# Altium schematic sheet AIRZAI_BASE_BD_REVC Sheet2.SchDoc
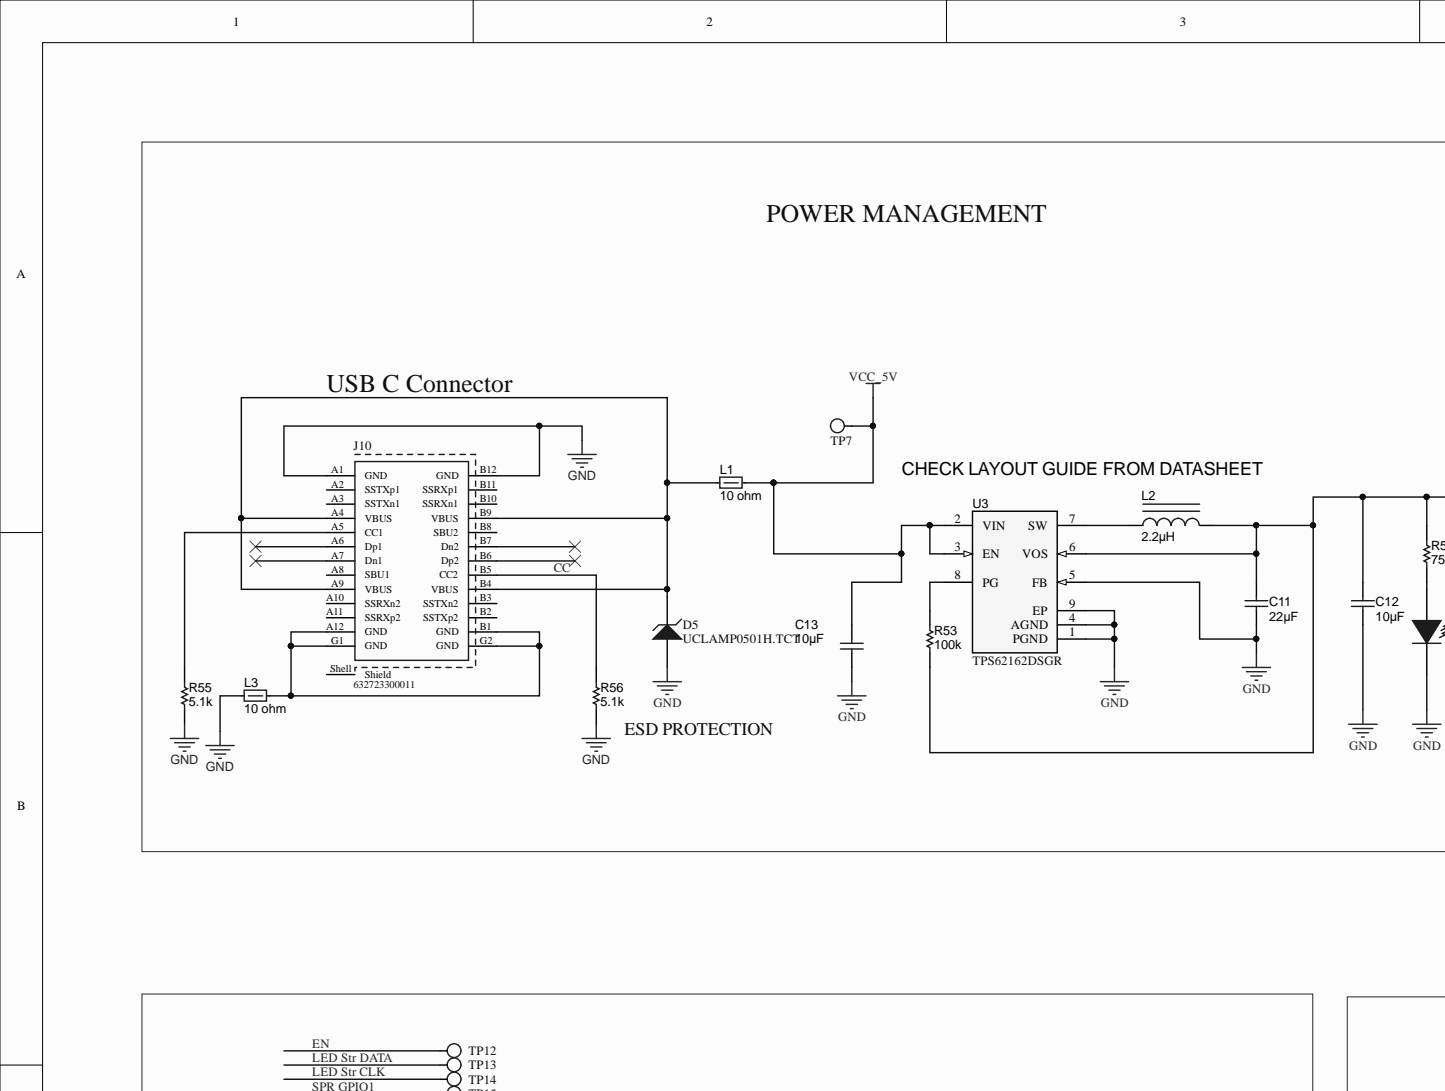
[diagram p0: AIRZAI_BASE_BD_REVC Sheet2.SchDoc, top left]
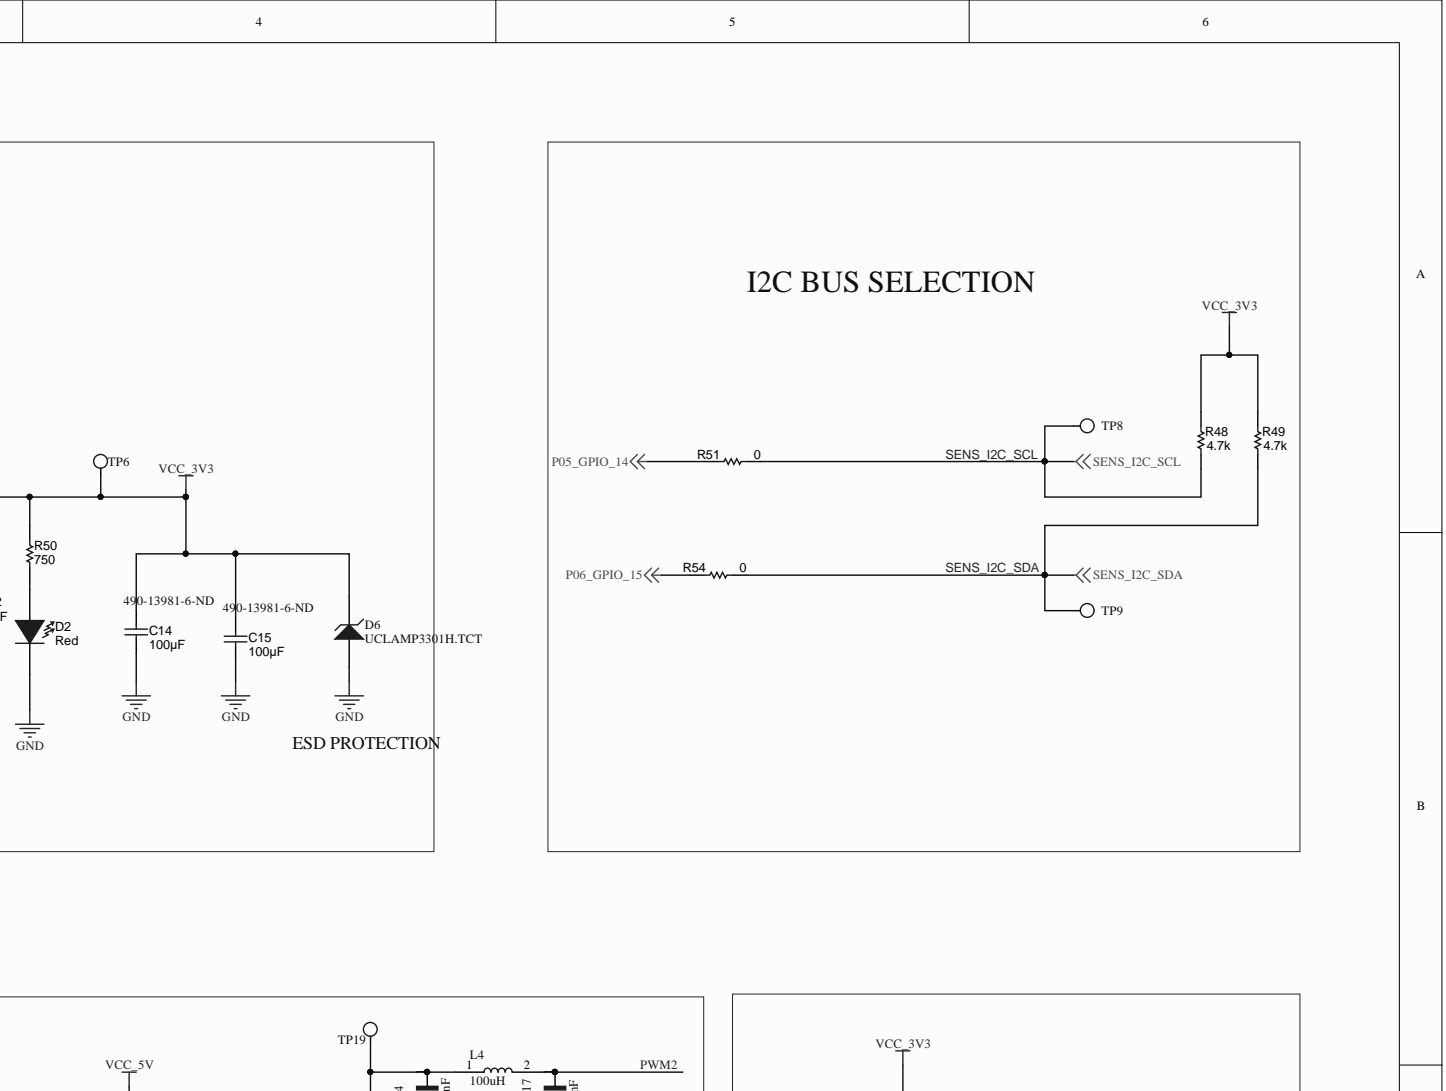
[diagram p1: AIRZAI_BASE_BD_REVC Sheet2.SchDoc, top right]
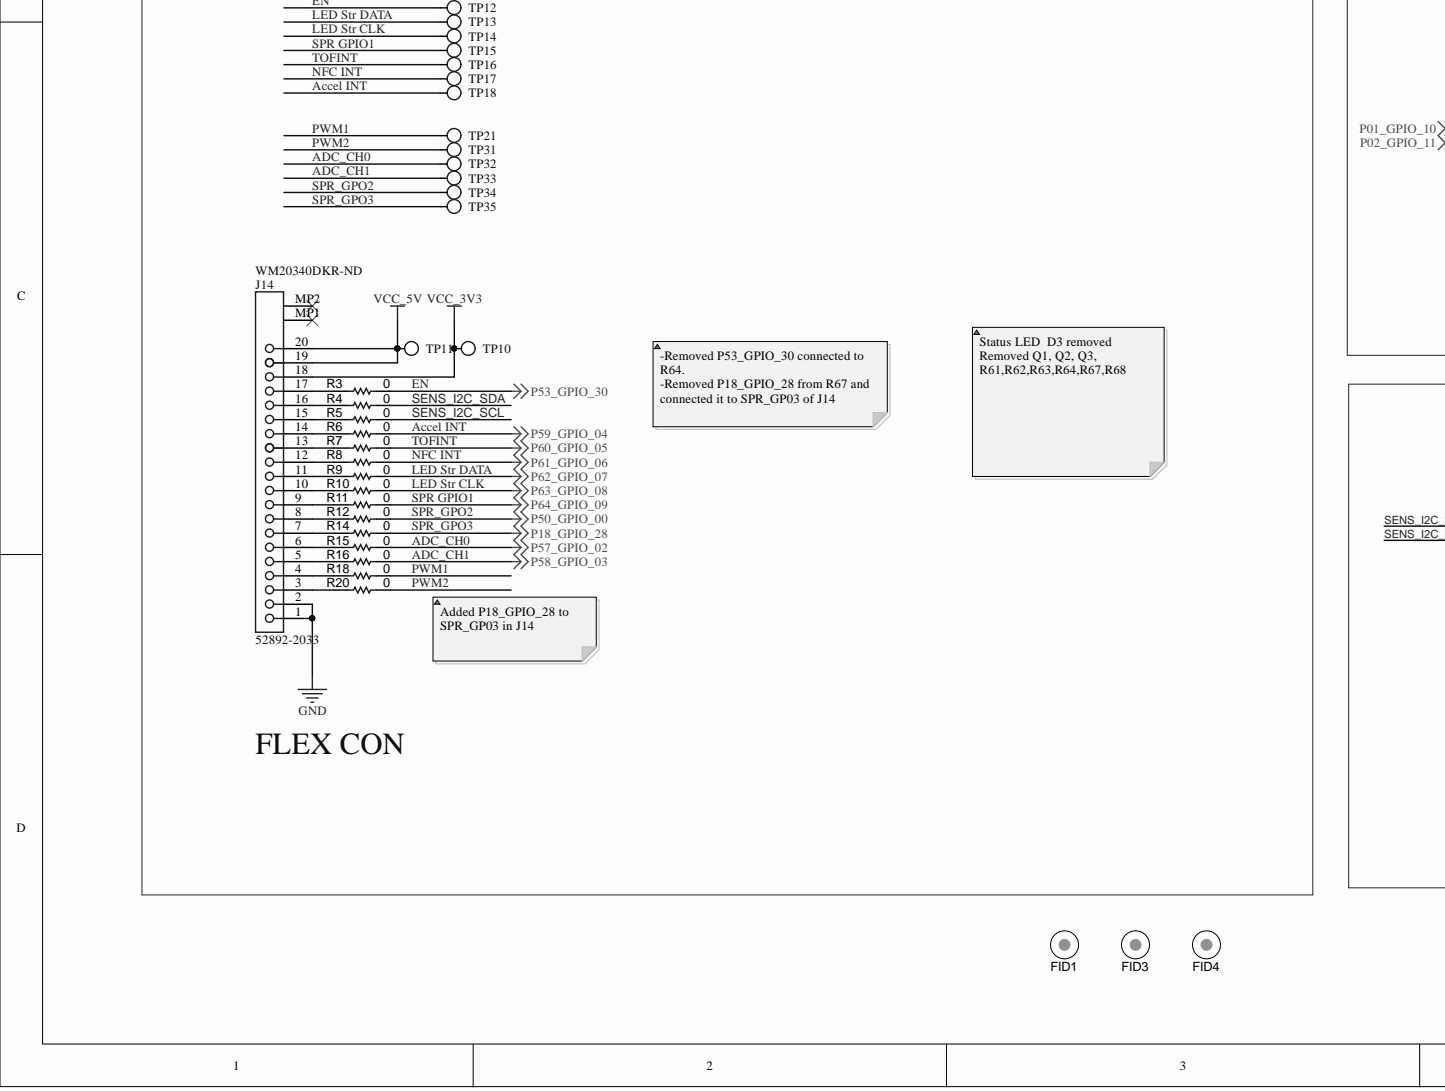
[diagram p2: AIRZAI_BASE_BD_REVC Sheet2.SchDoc, bottom left]
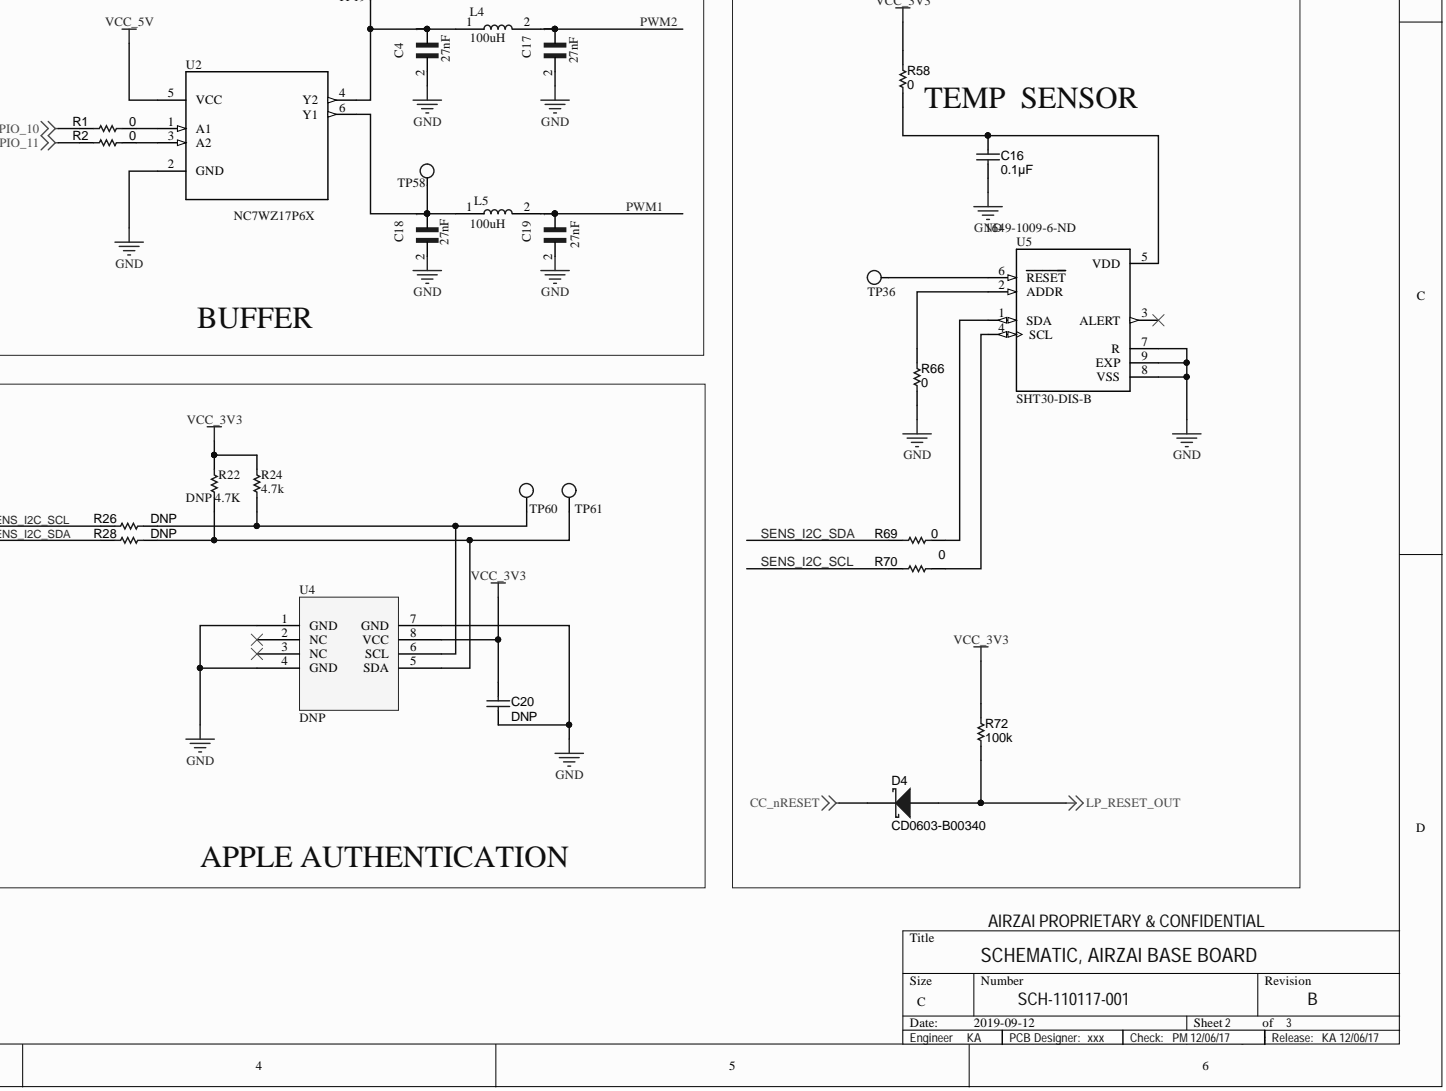
[diagram p3: AIRZAI_BASE_BD_REVC Sheet2.SchDoc, bottom right]

## Parts
C4  value 27nF  [1206CAP]  (hidden comment: GRM3195C1H273JA01D)
C11  ValueDisplayed: 22µF  [0805_HV]  (hidden comment: C2012X5R1C226K125AC)
C12  ValueDisplayed: 10µF  [0805_HV]  (hidden comment: GRM21BR61A106KE19L)
C13  ValueDisplayed: 10µF  [0805_HV]  (hidden comment: GRM21BR61A106KE19L)
C14  Supplier Part Number 3: 490-13981-6-ND  ValueDisplayed: 100µF  [1206_180]  (hidden comment: GRM31CR60J107ME39L)
C15  Supplier Part Number 3: 490-13981-6-ND  ValueDisplayed: 100µF  [1206_180]  (hidden comment: GRM31CR60J107ME39L)
C16  ValueDisplayed: 0.1µF  [0402]  (hidden comment: GRM155R61A104KA01D)
C17  value 27nF  [1206CAP]  (hidden comment: GRM3195C1H273JA01D)
C18  value 27nF  [1206CAP]  (hidden comment: GRM3195C1H273JA01D)
C19  value 27nF  [1206CAP]  (hidden comment: GRM3195C1H273JA01D)
C20  value DNP  [SM0402_BTD.1SM_ACT_H55_CAP_WSS]  (hidden comment: C1005X5R1A104K050BA)
D2  value Red  [SML-31_Red]  (hidden comment: SML-311UTT86)
D4  CD0603-B00340  [Diode_0603]
D5  UCLAMP0501H.TCT  [SOD-523]
D6  UCLAMP3301H.TCT  [SOD-523]
FID1  Fiducial  [Fiducial10-20]  (value not drawn)
FID3  Fiducial  [Fiducial10-20]  (value not drawn)
FID4  Fiducial  [Fiducial10-20]  (value not drawn)
J10  632723300011  [632723300011]
J14  52892-2033  Supplier Part Number 1: WM20340DKR-ND  [MOLX-52892-2033]
L1  value 10 ohm  [0402]  (hidden comment: BLM15AG100SN1D)
L2  ValueDisplayed: 2.2µH  [0805_HV]  (hidden comment: BRC2012T2R2MD)
L3  value 10 ohm  [0402]  (hidden comment: BLM15AG100SN1D)
L4  value 100uH  [0805_Inductor]  (hidden comment: MLF2012C101KTD25)
L5  value 100uH  [0805_Inductor]  (hidden comment: MLF2012C101KTD25)
R1  ERJ-2GE0R00X  [0402]  (value not drawn)
R2  ERJ-2GE0R00X  [0402]  (value not drawn)
R3  value 0  [0402]  (hidden comment: ERJ-2GE0R00X)
R4  value 0  [0402]  (hidden comment: ERJ-2GE0R00X)
R5  value 0  [0402]  (hidden comment: ERJ-2GE0R00X)
R6  value 0  [0402]  (hidden comment: ERJ-2GE0R00X)
R7  value 0  [0402]  (hidden comment: ERJ-2GE0R00X)
R8  value 0  [0402]  (hidden comment: ERJ-2GE0R00X)
R9  value 0  [0402]  (hidden comment: ERJ-2GE0R00X)
R10  value 0  [0402]  (hidden comment: ERJ-2GE0R00X)
R11  value 0  [0402]  (hidden comment: ERJ-2GE0R00X)
R12  value 0  [0402]  (hidden comment: ERJ-2GE0R00X)
R14  value 0  [0402]  (hidden comment: ERJ-2GE0R00X)
R15  value 0  [0402]  (hidden comment: ERJ-2GE0R00X)
R16  value 0  [0402]  (hidden comment: ERJ-2GE0R00X)
R18  value 0  [0402]  (hidden comment: ERJ-2GE0R00X)
R20  value 0  [0402]  (hidden comment: ERJ-2GE0R00X)
R22  Resistance (Ohms): DNP 4.7K  [0402]  (hidden comment: RMCF0402JT4K70)
R24  Resistance (Ohms): 4.7k  [0402]  (hidden comment: RMCF0402JT4K70)
R26  ValueDisplayed: DNP  [0402]  (hidden comment: ERJ-2GE0R00X)
R28  ValueDisplayed: DNP  [0402]  (hidden comment: ERJ-2GE0R00X)
R48  value 4.7k  [0402]
R49  value 4.7k  [0402]
R50  value 750  [0603]  (hidden comment: RC0603JR-07750RL)
R51  value 0  [0402]  (hidden comment: ERJ-2GE0R00X)
R53  value 100k  [0402]  (hidden comment: CRCW0402100KJNED)
R54  value 0  [0402]  (hidden comment: ERJ-2GE0R00X)
R55  ValueDisplayed: 5.1k  [0402]  (hidden comment: CRCW040210K0JNED)
R56  ValueDisplayed: 5.1k  [0402]  (hidden comment: CRCW040210K0JNED)
R58  value 0  [0402]  (hidden comment: ERJ-2GE0R00X)
R66  value 0  [0402]  (hidden comment: ERJ-2GE0R00X)
R69  value 0  [0402]  (hidden comment: ERJ-2GE0R00X)
R70  value 0  [0402]  (hidden comment: ERJ-2GE0R00X)
R72  value 100k  [0402]  (hidden comment: CRCW0402100KJNED)
TP6  WIREPT  [TP_0.9MM]  (value not drawn)
TP7  WIREPT  [TP_0.9MM]  (value not drawn)
TP8  WIREPT  [TP_0.9MM]  (value not drawn)
TP9  WIREPT  [TP_0.9MM]  (value not drawn)
TP10  WIREPT  [TP_0.9MM]  (value not drawn)
TP11  WIREPT  [TP_0.9MM]  (value not drawn)
TP12  WIREPT  [TP_0.9MM]  (value not drawn)
TP13  WIREPT  [TP_0.9MM]  (value not drawn)
TP14  WIREPT  [TP_0.9MM]  (value not drawn)
TP15  WIREPT  [TP_0.9MM]  (value not drawn)
TP16  WIREPT  [TP_0.9MM]  (value not drawn)
TP17  WIREPT  [TP_0.9MM]  (value not drawn)
TP18  WIREPT  [TP_0.9MM]  (value not drawn)
TP19  WIREPT  [TP_0.9MM]  (value not drawn)
TP21  WIREPT  [TP_0.9MM]  (value not drawn)
TP31  WIREPT  [TP_0.9MM]  (value not drawn)
TP32  WIREPT  [TP_0.9MM]  (value not drawn)
TP33  WIREPT  [TP_0.9MM]  (value not drawn)
TP34  WIREPT  [TP_0.9MM]  (value not drawn)
TP35  WIREPT  [TP_0.9MM]  (value not drawn)
TP36  WIREPT  [TP_0.9MM]  (value not drawn)
TP58  WIREPT  [TP_0.9MM]  (value not drawn)
TP60  TESTPOINT  [TP_0.9MM]  (value not drawn)
TP61  TESTPOINT  [TP_0.9MM]  (value not drawn)
U2  NC7WZ17P6X  [SC-70-NC7WZ17P6X]
U3  TPS62162DSGR  [DSG0008A]
U4  DNP  [XDFN-8P-Apple]
U5  SHT30-DIS-B  Supplier Part Number 1: 1649-1009-6-ND  [DFN250X250X100-9N]

## Nets
CC_nRESET: D4.1
GND: C4.2 C11.2 C12.2 C13.2 C14.2 C15.2 C16.2 C17.2 C18.2 C19.2 C20.2 D2.1 D5.A D6.A J10.A1(GND) J10.B12(GND) J14.1 J14.2 L3.2 R55.2 R56.2 R66.1 U2.2(GND) U3.1(PGND) U3.4(AGND) U3.5(FB) U3.9(EP) U4.1(GND) U4.4(GND) U4.7(GND) U5.7(R) U5.8(VSS) U5.9(EXP)
LP_RESET_OUT: D4.2 R72.2
P01_GPIO_10: R1.1
P02_GPIO_11: R2.1
P05_GPIO_14: R51.1
P06_GPIO_15: R54.1
VCC_3V3: C11.1 C12.1 C14.1 C15.1 C20.1 D6.K J14.18 L2.2 R22.2 R24.2 R48.1 R49.1 R50.2 R53.2 R58.2 R72.1 TP6.1 TP10.1 U3.6(VOS) U4.8(VCC)
VCC_5V: C13.1 J14.19 J14.20 L1.2 TP7.1 TP11.1 U2.5(VCC) U3.2(VIN) U3.3(EN)
Accel INT: R6.2 TP18.1
ADC_CH0: R15.2 TP32.1
ADC_CH1: R16.2 TP33.1
CC: J10.B5(CC2) R56.1
EN: R3.2 TP12.1
LED Str CLK: R10.2 TP14.1
LED Str DATA: R9.2 TP13.1
NFC INT: R8.2 TP17.1
PWM1: C19.1 L5.2 R18.2 TP21.1
PWM2: C17.1 L4.2 R20.2 TP31.1
SENS_I2C_SCL: R5.2 R26.1 R48.2 R51.2 R70.1 TP8.1
SENS_I2C_SDA: R4.2 R28.1 R49.2 R54.2 R69.1 TP9.1
SPR GPIO1: R11.2 TP15.1
SPR_GPO2: R12.2 TP34.1
SPR_GPO3: R14.2 TP35.1
TOFINT: R7.2 TP16.1
NetC4_1: C4.1 L4.1 TP19.1 U2.4(Y2)
NetC16_1: C16.1 R58.1 U5.5(VDD)
NetC18_1: C18.1 L5.1 TP58.1 U2.6(Y1)
NetD2_2: D2.2 R50.1
NetD5_K: D5.K J10.A4(VBUS) J10.A9(VBUS) J10.B4(VBUS) J10.B9(VBUS) L1.1
NetJ10_A2: J10.A2(SSTXp1)
NetJ10_A3: J10.A3(SSTXn1)
NetJ10_A5: J10.A5(CC1) R55.1
NetJ10_A6: J10.A6(Dp1)
NetJ10_A7: J10.A7(Dn1)
NetJ10_A8: J10.A8(SBU1)
NetJ10_A10: J10.A10(SSRXn2)
NetJ10_A11: J10.A11(SSRXp2)
NetJ10_A12: J10.A12(GND) J10.B1(GND) J10.G1(GND) J10.G2(GND) L3.1
NetJ10_B2: J10.B2(SSTXp2)
NetJ10_B3: J10.B3(SSTXn2)
NetJ10_B6: J10.B6(Dp2)
NetJ10_B7: J10.B7(Dn2)
NetJ10_B8: J10.B8(SBU2)
NetJ10_B10: J10.B10(SSRXn1)
NetJ10_B11: J10.B11(SSRXp1)
NetJ10_Shell: J10.Shell(Shield)
NetJ14_3: J14.3 R20.1
NetJ14_4: J14.4 R18.1
NetJ14_5: J14.5 R16.1
NetJ14_6: J14.6 R15.1
NetJ14_7: J14.7 R14.1
NetJ14_8: J14.8 R12.1
NetJ14_9: J14.9 R11.1
NetJ14_10: J14.10 R10.1
NetJ14_11: J14.11 R9.1
NetJ14_12: J14.12 R8.1
NetJ14_13: J14.13 R7.1
NetJ14_14: J14.14 R6.1
NetJ14_15: J14.15 R5.1
NetJ14_16: J14.16 R4.1
NetJ14_17: J14.17 R3.1
NetJ14_MP1: J14.MP1
NetJ14_MP2: J14.MP2
NetL2_1: L2.1 U3.7(SW)
NetR1_2: R1.2 U2.1(A1)
NetR2_2: R2.2 U2.3(A2)
NetR22_1: R22.1 R28.2 TP61.1 U4.5(SDA)
NetR24_1: R24.1 R26.2 TP60.1 U4.6(SCL)
NetR53_1: R53.1 U3.8(PG)
NetR66_2: R66.2 U5.2(ADDR)
NetR69_2: R69.2 U5.1(SDA)
NetR70_2: R70.2 U5.4(SCL)
NetTP36_1: TP36.1 U5.6(RESET)
NetU4_2: U4.2(NC)
NetU4_3: U4.3(NC)
NetU5_3: U5.3(ALERT)

## Library data of the parts
C4 C17-C19 = GRM3195C1H273JA01D: 1206 27 nF 50 V Â±5% Tolerance C0G SMT Multilayer Ceramic Capacitor; MF: Murata Electronics; Availability: Unavailable; Component Kind: Standard; Library Name: GRM3195C1H273JA01D.IntLib; MP: GRM3195C1H273JA01D; Package: 1206 Murata; Price: None; SnapEDA_Link: https://www.snapeda.com/parts/GRM3195C1H273JA01D/Murata%20Electronics%20North%20America/view-part/?ref=snap
C11 = C2012X5R1C226K125AC: CAP, CERM, 22 µF, 16 V, +/- 10%, X5R, 0805; Manufacturer: TDK; AEC Category: Q200; Cap_Case: 0805; Cap_F: 0.000022; CompType: Capacitor; Polarized: N; SizeArea_mm2: 2.5; SizeAreaWB_mm2: 6.75; SizeX_mm: 2; SizeY_mm: 1.25; SizeZ_mm: 1.45; TaMax_C: 85; TaMin_C: -55; Tolerance_%: 10; U/M: EA; Value: 22uF; Value1: Unknown; Value2: 16V; VDC_V: 16; AEC Qualified: ?; Component Kind: Standard; ComponentLink1Description: Datasheet link; ComponentLink1URL: http://product.tdk.com/capacitor/mlcc/en/documents/C2012X5R1C226K125AC.pdf; LatestRevisionDate: 2016-07-02; Library Name: Capacitor_Ceramic.IntLib; MountType: SMT; PackageReference: 0805; PartNumber: C2012X5R1C226K125AC; Published: 2008-06-19; RoHS: Y; Signal Integrity: Cap; Simulation: Cap; Supplier 1: Digi-Key; Supplier 2: Mouser; Supplier 3: Farnell; Supplier Part Number 1: 445-6797-1-ND; Supplier Part Number 2: 810-C2012X5R1C226K; Supplier Part Number 3: 2346925; SymbolVersion: 2008-07-09; Technology: Ceramic; TempCo: X5R; Publisher: Texas Instruments
C12 C13 = GRM21BR61A106KE19L: CAP, CERM, 10 µF, 10 V, +/- 10%, X5R, 0805; Manufacturer: MuRata; AEC Category: Q200; Cap_Case: 0805; Cap_F: 0.00001; CompType: Capacitor; ESR_ohm: 0.002; Polarized: N; SizeArea_mm2: 2.5; SizeAreaWB_mm2: 6.75; SizeX_mm: 2; SizeY_mm: 1.25; SizeZ_mm: 1.45; TaMax_C: 85; TaMin_C: -55; Tolerance_%: 10; U/M: EA; Value: 10uF; Value1: 0.002 ohm; Value2: 10V; AEC Qualified: ?; Component Kind: Standard; ComponentLink1Description: Datasheet link; ComponentLink1URL: http://search.murata.co.jp/Ceramy/PnsearchViewAction.do?HnOFG=ON&HnNFG=ON&SEL_CNT=50&sLang=en&sKey=GRM21BR61A106KE19L&x=9&y=9&search=startwith; LatestRevisionDate: 2016-07-02; Library Name: Capacitor_Ceramic.IntLib; MountType: SMT; PackageReference: 0805; PartNumber: GRM21BR61A106KE19L; Published: 2008-06-19; RoHS: Y; Signal Integrity: Cap; Simulation: Cap; Supplier 1: Digi-Key; Supplier 2: Mouser; Supplier 3: Farnell; Supplier Part Number 1: 490-1709-1-ND; Supplier Part Number 2: 81-GRM21BR61A106KE19; Supplier Part Number 3: 1828805; SymbolVersion: 2008-07-09; Technology: Ceramic; TempCo: X5R; VDC_V: 10; Publisher: Texas Instruments
C14 C15 = GRM31CR60J107ME39L: CAP, CERM, 100 µF, 6.3 V, +/- 20%, X5R, 1206; Manufacturer: MuRata; AEC Category: Q200; Cap_Case: 1206; Cap_F: 0.0001; CompType: Capacitor; ESR_ohm: 0.003; Polarized: N; SizeArea_mm2: 5.12; SizeAreaWB_mm2: 10.92; SizeX_mm: 3.2; SizeY_mm: 1.6; SizeZ_mm: 1.8; TaMax_C: 85; TaMin_C: -55; Tolerance_%: 20; U/M: EA; Value: 100uF; Value1: 0.003 ohm; Value2: 6.3V; VDC_V: 6.3; AEC Qualified: ?; Component Kind: Standard; ComponentLink1Description: Datasheet link; ComponentLink1URL: http://search.murata.co.jp/Ceramy/PnsearchViewAction.do?HnOFG=ON&HnNFG=ON&SEL_CNT=50&sLang=en&sKey=GRM31CR60J107ME39L&x=9&y=9&search=startwith; LatestRevisionDate: 2016-07-02; Library Name: Capacitor_Ceramic.IntLib; MountType: SMT; PackageReference: 1206; PartNumber: GRM31CR60J107ME39L; Published: 2008-06-19; RoHS: Y; Signal Integrity: Cap; Simulation: Cap; Supplier 1: Mouser; Supplier 2: Farnell; Supplier 3: Digi-Key; Supplier Part Number 1: 81-GRM31CR60J107ME9L; Supplier Part Number 2: 1735535; SymbolVersion: 2008-07-09; Technology: Ceramic; TempCo: X5R; Publisher: Texas Instruments
C16 = GRM155R61A104KA01D: CAP, CERM, 0.1 µF, 10 V, +/- 10%, X5R, 0402; Manufacturer: MuRata; AEC Category: Q200; Cap_F: 0.0000001; CompType: Capacitor; Polarized: N; SizeArea_mm2: 0.5; SizeAreaWB_mm2: 3; SizeX_mm: 1; SizeZ_mm: 0.6; TaMax_C: 85; TaMin_C: -55; Tolerance_%: 10; U/M: EA; Value: 0.1uF; Value1: Unknown; Value2: 10V; AEC Qualified: ?; Cap_Case: 0402; Component Kind: Standard; ComponentLink1Description: Datasheet link; ComponentLink1URL: http://search.murata.co.jp/Ceramy/PnsearchViewAction.do?HnOFG=ON&HnNFG=ON&SEL_CNT=50&sLang=en&sKey=GRM155R61A104KA01D&x=9&y=9&search=startwith; LatestRevisionDate: 2016-08-14; Library Name: Capacitor_Ceramic.IntLib; MountType: SMT; PackageReference: 0402; PartNumber: GRM155R61A104KA01D; Published: 2008-06-19; RoHS: Y; Signal Integrity: Cap; Simulation: Cap; SizeY_mm: 0.5; Supplier 1: Digi-Key; Supplier 2: Mouser; Supplier 3: Farnell; Supplier Part Number 1: 490-1318-1-ND; Supplier Part Number 2: 81-GRM155R61A104KA01; Supplier Part Number 3: 1828862; SymbolVersion: 2008-07-09; Technology: Ceramic; TempCo: X5R; VDC_V: 10; Publisher: Texas Instruments
C20 = C1005X5R1A104K050BA: CAP, CERM, 0.1 µF, 10 V, +/- 10%, X5R, 0402; Manufacturer: TDK; Cap_Case: SM0402_BTD.1SM_ACT; Cap_F: 0.0000001; CompType: Capacitor; Polarized: N; TaMax_C: 85; TaMin_C: -55; Tolerance_%: 10; U/M: EA; Value1: Unknown; Value2: 10V; Component Kind: Standard; ComponentLink1Description: Datasheet link; ComponentLink1URL: http://product.tdk.com/en/catalog/datasheets/mlcc_commercial_general_en.pdf; LatestRevisionDate: 2015-02-23; MountType: SMT; PackageReference: 0402; PartNumber: C1005X5R1A104K050BA; Published: 2008-06-19; RoHS: Y; Supplier 1: Digi-Key; Supplier 2: Mouser; Supplier 3: Farnell; Supplier Part Number 1: 445-1265-1-ND; Supplier Part Number 2: 810-C1005X5R1A104K; Supplier Part Number 3: 2368215; SymbolVersion: 2008-07-09; Technology: Ceramic; TempCo: X5R; ValueDisplayed: DNP; VDC_V: 10; Library Name: AIRZAI_MBD_REVB2.IntLib; Publisher: Texas Instruments
D2 = SML-311UTT86: LED, Red, SMD; Manufacturer: Rohm; Io_A: 0.002; Type: AlInGaP; U/M: EA; Value1: W; Value2: 1.8V; Vf_V: 1.8; Color: red; Component Kind: Standard; ComponentLink1Description: Datasheet link; ComponentLink1URL: http://rohmfs.rohm.com/en/products/databook/datasheet/opto/led/chip_mono/sml-31.pdf; CompType: LED; LatestRevisionDate: 2016-06-29; Library Name: LED.IntLib; MountType: SMT; PackageReference: LED, 1.6x.8x.8mm; PartNumber: SML-311UTT86; Published: 2008-07-11; RoHS: Y; Signal Integrity: Diode; Supplier 1: Digi-Key; Supplier 2: Mouser; Supplier 3: Farnell; Supplier Part Number 1: 511-1304-1-ND; Supplier Part Number 2: 755-SML-311UTT86; Supplier Part Number 3: 1685068; SymbolVersion: 2008-11-06; Publisher: Texas Instruments
D4 = CD0603-B00340: Diode, Schottky, 45 V, 0.03 A, 0603 Diode; Manufacturer: Bourns; AEC Category: Q101; Io_A: 0.03; SizeArea_mm2: 1.53; SizeAreaWB_mm2: 5.13; SizeX_mm: 1.7; SizeY_mm: 0.9; SizeZ_mm: 0.75; TJmax_C: 125; U/M: EA; Value: 45V; Value1: 0.03A; Value2: 0.37V; Vf_V: 0.37; Vr_V: 45; AEC Qualified: ?; Component Kind: Standard; ComponentLink1Description: Datasheet link; ComponentLink1URL: http://www.bourns.com/pdfs/SBCD0603_1005.pdf; CompType: Diode; LatestRevisionDate: 2016-07-03; Library Name: Diode.IntLib; MountType: SMT; PackageReference: 0603 Diode; PartNumber: CD0603-B00340; Published: 2008-06-26; RoHS: Y; Signal Integrity: Diode; Supplier 1: Mouser; Supplier 2: Farnell; Supplier Part Number 1: 652-CD0603-B00340; Supplier Part Number 2: 1456534; SymbolVersion: 2008-12-08; Type: Schottky; Publisher: Texas Instruments
D5 = UCLAMP0501H.TCT: TVS DIODE 5V 12.5V SOD523; Manufacturer: Semtech; Breakdown Voltage: 6V; Capacitance: 160pF; Direction: Unidirectional; Element Configuration: Single; Leakage Current: 5uA; Max Power Dissipation: 240W; Number of Channels: 1; Peak Pulse Current: 16A; Power Line Protection: No; Test Current: 1mA; Clamping Voltage: 12.5V; Component Kind: Standard; ComponentLink1Description: https://www.semtech.com/uploads/documents/uclamp3301h.pdf?src-supplier=Digi-Key; ComponentLink1URL: https://www.semtech.com/uploads/documents/uclamp3301h.pdf?src-supplier=Digi-Key; ComponentLink2Description: https://www.verical.com/server-webapp/html/getDatasheet?partID=141337&src-supplier=Verical; ComponentLink2URL: https://www.verical.com/server-webapp/html/getDatasheet?partID=141337&src-supplier=Verical; ComponentLink3Description: http://www.semtech.com/images/datasheet/uclamp3301h.pdf?src-supplier=Avnet; ComponentLink3URL: http://www.semtech.com/images/datasheet/uclamp3301h.pdf?src-supplier=Avnet; ComponentLink4Description: http://www.semtech.com/images/datasheet/uclamp0501h.pdf?src-supplier=Future+Electronics; ComponentLink4URL: http://www.semtech.com/images/datasheet/uclamp0501h.pdf?src-supplier=Future+Electronics; ComponentLink5Description: https://www.verical.com/server-webapp/html/getDatasheet?partID=51047&src-supplier=Verical; ComponentLink5URL: https://www.verical.com/server-webapp/html/getDatasheet?partID=51047&src-supplier=Verical; ComponentLink6Description: http://www.semtech.com/images/datasheet/uclamp0501h.pdf?src-supplier=Digi-Key; ComponentLink6URL: http://www.semtech.com/images/datasheet/uclamp0501h.pdf?src-supplier=Digi-Key; ComponentLink7Description: https://www.semtech.com/uploads/documents/uclamp0501h.pdf?src-supplier=Digi-Key; ComponentLink7URL: https://www.semtech.com/uploads/documents/uclamp0501h.pdf?src-supplier=Digi-Key; ComponentLink8Description: http://www.semtech.com/images/datasheet/uclamp0501h.pdf?src-supplier=Element14; ComponentLink8URL: http://www.semtech.com/images/datasheet/uclamp0501h.pdf?src-supplier=Element14; ComponentLink9Description: http://www.farnell.com/datasheets/1796175.pdf?src-supplier=Element14; ComponentLink9URL: http://www.farnell.com/datasheets/1796175.pdf?src-supplier=Element14; ComponentLink10Description: http://www.semtech.com/images/datasheet/uclamp0501h.pdf?src-supplier=Avnet; ComponentLink10URL: http://www.semtech.com/images/datasheet/uclamp0501h.pdf?src-supplier=Avnet; Composition: Zener; Depth: 0.9mm; Lead Free: Lead Free; Length: 1.3mm; Manufacturer Part Number: UCLAMP0501H.TCT; Max Breakdown Voltage: 6V; Max Operating Temperature: 125°C; Min Breakdown Voltage: 6V; Min Operating Temperature: -55°C; Mount: Surface Mount; Number of Elements: 1; Number of Pins: 2; Number of Unidirectional Channels: 1; Packaging: Tape and Reel; PartNumber: UCLAMP0501H.TCT; Peak Pulse Power: 240W; Polarity: Unidirectional; Pricing 1: 1=0.65, 10=0.568, 25=0.5028, 100=0.438, 250=0.38124, 500=0.32446, 1000=0.25956 (USD); Radiation Hardening: No; REACH SVHC: No SVHC; Reverse Breakdown Voltage: 6V; Reverse Standoff Voltage: 5V; Signal Integrity: D Zener; Simulation: ZENER; Stock 1: 63859; Supplier 1: Digi-Key; Supplier Part Number 1: UCLAMP0501HDKR-ND; Voltage Rating (DC): 5V; Width: 900um; Working Voltage: 5V; Library Name: AIRZAI_BASE_BD_REVA.SCHLIB
D6 = UCLAMP3301H.TCT: TVS DIODE 3.3V 8V SOD523; Manufacturer: Semtech; Capacitance: 30pF; Direction: Unidirectional; Element Configuration: Single; Leakage Current: 500nA; Max Power Dissipation: 40W; Min Breakdown Voltage: 3.5V; Number of Channels: 1; Peak Pulse Current: 5A; Power Line Protection: No; Clamping Voltage: 8V; Component Kind: Standard; ComponentLink1Description: https://www.semtech.com/uploads/documents/uclamp3301h.pdf?src-supplier=Digi-Key; ComponentLink1URL: https://www.semtech.com/uploads/documents/uclamp3301h.pdf?src-supplier=Digi-Key; ComponentLink2Description: https://www.verical.com/server-webapp/html/getDatasheet?partID=141337&src-supplier=Verical; ComponentLink2URL: https://www.verical.com/server-webapp/html/getDatasheet?partID=141337&src-supplier=Verical; ComponentLink3Description: http://www.semtech.com/images/datasheet/uclamp3301h.pdf?src-supplier=Avnet; ComponentLink3URL: http://www.semtech.com/images/datasheet/uclamp3301h.pdf?src-supplier=Avnet; Composition: Zener; Depth: 0.9mm; Lead Free: Lead Free; Length: 1.3mm; Manufacturer Part Number: UCLAMP3301H.TCT; Max Operating Temperature: 125°C; Min Operating Temperature: -55°C; Mount: Surface Mount; Number of Elements: 1; Number of Pins: 2; Number of Unidirectional Channels: 1; Operating Supply Voltage: 3.3V; Packaging: Tape and Reel; PartNumber: UCLAMP3301H.TCT; Peak Pulse Power: 40W; Polarity: Unidirectional; Pricing 1: 1=0.71, 10=0.554, 50=0.554, 100=0.357, 1000=0.286, 10000=0.225 (USD); Radiation Hardening: No; REACH SVHC: No SVHC; Reverse Standoff Voltage: 3.3V; Signal Integrity: D Zener; Simulation: ZENER; Stock 1: 89004; Supplier 1: Mouser; Supplier Part Number 1: 947-UCLAMP3301H.TCT; Thickness: 700um; Voltage Rating (DC): 3.3V; Width: 900um; Working Voltage: 3.3V; Library Name: AIRZAI_BASE_BD_REVA.SCHLIB
FID1 FID3 FID4 = Fiducial: Fiducial mark.  There is nothing to buy or mount.; SymbolCreator: codlsc; ClassName: FiducialMark; Component Kind: Standard; CompType: Fiducial; LatestRevisionDate: 2016-05-09; LatestRevisionNote: Added Alternate PartNumber and Alternate Manufacturer parameters to appropriate components.; Library Name: MiscParts.IntLib; Manufacturer: N/A; MountType: N/A; PackageReference: N/A; PartNumber: N/A; SymbolVersion: 2009-11-4; Publisher: Texas Instruments
J10 = 632723300011: USB 3.1 Type C Receptacle WR-COM, Horizontal, SMT / THT; Contact Resistance: 30 mOhm; Rated Current: 5 A; Working Voltage: 20 VDC; Component Kind: Standard; ComponentLink1Description: Manufacturer; ComponentLink1URL: http://www.we-online.de; ComponentLink2Description: Datasheet; ComponentLink2URL: https://katalog.we-online.de/em/datasheet/632723x00011%282%29.pdf; Connector Type: USB 3.1 Type C; Gender: Receptacle; Library Name: Altium_WR-COM_USB-Connectors (rev18b).IntLib; Mounting Technology: SMT / THT; Operating Temperature: -40 to 105 degC; Orientation: Horizontal; Packaging: Tape and Reel; Part Number: 632723300011; Published Date: September 2018; Publisher: Wurth Elektronik
J14 = CMP-1241-00473-1: 0.5 mm Pitch Easy-On(TM) Type FPC Connector, 2.0 mm Mated Height, Right Angle, ZIF, SMT, Bottom Contact, 20 Circuits, -40 to 85 degC, ELV and RoHS Compliant, Tape and Reel; Manufacturer: Molex; Circuits (Loaded): 20; Current (Max per Contact): 0.5A; Entry Angle: 90degrees Angle; Mated Height: 2.00mm; Material - Metal: Phosphor Bronze; Material - Plating Mating: Silver, Tin-Bismuth; PCB Locator: No; PCB Retention: Yes; Pitch - Mating Interface: 0.50mm; Voltage (Max): 50V; Component Kind: Standard; ComponentLink1Description: Manufacturer URL; ComponentLink1URL: http://www.molex.com/molex/index.jsp; ComponentLink2Description: Datasheet; ComponentLink2URL: http://www.molex.com/webdocs/datasheets/pdf/en-us/0528922033_FFC_FPC_CONNECTORS.pdf; ComponentLink3Description: Package Specification; ComponentLink3URL: http://www.molex.com/pdm_docs/sd/528922033_sd.pdf; Contact Position: Bottom; Durability (mating cycles max): 20; Library Name: Altium Content Vault; Material - Plating Termination: Silver, Tin-Bismuth; Mounting Technology: Surface Mount; Number of Rows: 1; Orientation: Right Angle; PackageDescription: 20-Lead FPC Connector, Pitch 0.5 mm; PackageReference: 52892-2033; PackageVersion: Rev. A, 05/2013; Packing: Tape and Reel; PartNumber: 52892-2033; Pitch - Termination Interface: 0.50mm; Polarized to PCB: No; RoHS: TRUE; Stackable: No; Supplier 1: Digi-Key
L1 L3 = BLM15AG100SN1D: Ferrite Bead, 10 ohm @ 100 MHz, 1 A, 0402; Manufacturer: MuRata; DCR_ohm: 0.025; Imax_A: 1; Value1: 0.025 ohm; Value2: 1A; ZTyp@100MHz_Ohm: 10; Component Kind: Standard; ComponentLink1Description: Datasheet link; ComponentLink1URL: http://search.murata.co.jp/Ceramy/image/img/PDF/ENG/L0110S0100BLM15AG_SN.pdf; CompType: Ferrite Bead; LatestRevisionDate: 2016-06-23; Library Name: FerriteBead.IntLib; MountType: SMT; PackageReference: 0402; PartNumber: BLM15AG100SN1D; Published: 2014-04-30; RoHS: Y; Supplier 1: Digi-Key; Supplier 2: Mouser; Supplier 3: Farnell; Supplier Part Number 1: 490-5176-1-ND; Supplier Part Number 2: 81-BLM15AG100SN1D; Supplier Part Number 3: 1515758; SymbolVersion: 2009-06-02; Publisher: Texas Instruments
L2 = BRC2012T2R2MD: Inductor, Wirewound, 2.2 µH, 1 A, 0.143 ohm, SMD; Manufacturer: Taiyo Yuden; AEC Category: Q200; DCR_ohm: 0.143; Imax_A: 1; Ind_H: 2.2E-6; Irms: 1A @ 40C rise; Isat: 1.1A @ 30% inductance drop; Value: 2.2uH; Value2: 1A; AEC Qualified: ?; Component Kind: Standard; ComponentLink1Description: Datasheet link; ComponentLink1URL: http://www.yuden.co.jp/ut/product/category/inductor/BRC2012T2R2MD.pdf; CompType: Inductor; LatestRevisionDate: 2016-06-28; Library Name: Inductor_Other.IntLib; Material: ?; MountType: SMT; PackageReference: 0805; PartNumber: BRC2012T2R2MD; Published: 2008-06-26; RoHS: Y; Signal Integrity: Inductor; Simulation: Inductor; Supplier 1: Digi-Key; Supplier 2: Mouser; Supplier 3: Farnell; Supplier Part Number 1: 587-2905-1-ND; Supplier Part Number 2: 963-BRC2012T2R2MD; Supplier Part Number 3: 2369453; SymbolVersion: 2008-07-23; Technology: Wirewound; Value1: 0.143 ohm; Publisher: Texas Instruments
L4 L5 = MLF2012C101KTD25: Fixed Ind 100uh 2ma 3.1 Ohm Smd; MF: TDK; Availability: Unavailable; Component Kind: Standard; Library Name: MLF2012C101KTD25.IntLib; MP: MLF2012C101KTD25; Package: 0805 TDK; Price: None; SnapEDA_Link: https://www.snapeda.com/parts/MLF2012C101KTD25/TDK-Lambda%20Americas%20Inc/view-part/?ref=snap
R1 R2 R26 R28 = ERJ-2GE0R00X: RES, 0, 5%, 0.063 W, 0402; Manufacturer: Panasonic; AEC Category: Q200; CompType: Resistor; EIA_Code: E24; MF: Panasonic Electronic Components; Power_W: 0.063; Res_ohm: 0; SizeArea_mm2: 0.5; SizeX_mm: 1; SizeZ_mm: 0.6; TempCo: 600 ppm/C; Tolerance_%: 5; U/M: EA; Value2: 0.063W; Value_Code: 0000; VDC_V: 50; AEC Qualified: ?; Component Kind: Standard; ComponentLink1Description: Datasheet link; ComponentLink1URL: http://www.panasonic.com/industrial/components/pdf/AOA0000CE1.pdf; Digi-Key_Part_Number: P0.0JCT-ND; Digi-Key_Purchase_URL: https://www.digikey.ca/products/en?keywords=P0.0JCT-ND; LatestRevisionDate: 2016-07-01; Library Name: Resistor_0402.IntLib; MountType: SMT; MP: ERJ-2GE0R00X; Package: 0402 (1005 Metric); PackageReference: 0402; PartNumber: ERJ-2GE0R00X; Published: 2008-06-26; RoHS: Y; Signal Integrity: Resistor; Simulation: Resistor; SizeY_mm: 0.5; Supplier 1: Digi-Key; Supplier 2: Mouser; Supplier 3: Farnell; Supplier Part Number 1: P0.0JCT-ND; Supplier Part Number 2: 667-ERJ-2GE0R00X; Supplier Part Number 3: 2059190; SymbolVersion: 2009-05-29; Technology: Thick Film; Value1: 5%; Publisher: Texas Instruments
  R1 R2: ValueDisplayed: 0
R3-R12 R14-R16 R18 R20 R51 R54 R58 R66 R69 R70 = ERJ-2GE0R00X: RES, 0, 5%, 0.063 W, 0402; Manufacturer: Panasonic; AEC Category: Q200; CompType: Resistor; EIA_Code: E24; Power_W: 0.063; SizeArea_mm2: 0.5; SizeX_mm: 1; SizeZ_mm: 0.6; TempCo: 600 ppm/C; Tolerance_%: 5; U/M: EA; Value2: 0.063W; Value_Code: 0000; VDC_V: 50; AEC Qualified: ?; Component Kind: Standard; ComponentLink1Description: Datasheet link; ComponentLink1URL: http://www.panasonic.com/industrial/components/pdf/AOA0000CE1.pdf; LatestRevisionDate: 2016-07-01; Library Name: Resistor_0402.IntLib; MountType: SMT; PackageReference: 0402; PartNumber: ERJ-2GE0R00X; Published: 2008-06-26; Res_ohm: 0; RoHS: Y; Signal Integrity: Resistor; Simulation: Resistor; SizeY_mm: 0.5; Supplier 1: Digi-Key; Supplier 2: Mouser; Supplier 3: Farnell; Supplier Part Number 1: P0.0JCT-ND; Supplier Part Number 2: 667-ERJ-2GE0R00X; Supplier Part Number 3: 2059190; SymbolVersion: 2009-05-29; Technology: Thick Film; Value1: 5%; ValueDisplayed: 0; Publisher: Texas Instruments
R22 R24 = RES-2: Chip Resistor, 4.7 KOhm, +/- 5%, 0.063 W, -55 to 155 degC, 0402 (1005 Metric), RoHS, Tape and Reel; Manufacturer: Stackpole-Electronics-Inc.; Power (Watts): 0.063W,1/16W; Temperature Coefficient: ±100ppm/°C; Category: Resistors; Component Kind: Standard; Composition: ThickFilm; Datasheet URL: https://www.seielect.com/Catalog/SEI-RMCF_RMCP.pdf; Datasheet Version: 01/2017; Family: ChipResistor-SurfaceMount; Features: AutomotiveAEC-Q200; Manufacturer Part.No: RMCF0402JT4K70; Manufacturer URL: https://www.seielect.com/; Mounting Technology: SurfaceMount; Number of Terminations: 2; Operating Temperature: -55°C~155°C; Package / Case: 0402(1005Metric); Package Description: 2-Pin Surface Mount Device, Body 1 x 0.5 mm; Package Reference: 0402; Packaging: Digi-Reel®; Series: RMCF; Supplier 1: Digi-Key; Supplier Device Package: 0402; Supplier Part Number 1: RMCF0402JT4K70-ND; Tolerance: ±5%; Library Name: AIRZAI_BASE_BD_REVA.SCHLIB
R48 R49 = CRCW04023K30JNED: RES SMD 4.7K OHM 1% 1/16W 0402; Manufacturer: Yageo; Manufacturer Part Number: RC0402FR-074K7L; Power Rating: 62.5mW; Resistance: 4.7kR; Temperature Coefficient: 100ppm/°C; Voltage Rating: 50V; Case/Package: 0402; Case Code (Imperial): 0402; Case Code (Metric): 1005; Component Kind: Standard; ComponentLink1Description: Datasheet link; ComponentLink1URL: http://www.vishay.com/docs/20035/dcrcwe3.pdf; ComponentLink2Description: http://documents.rs-components.com/techlib://itc_doclist_GB.htm?stockno=6184558&src-supplier=RSComponents; ComponentLink2URL: http://documents.rs-components.com/techlib://itc_doclist_GB.htm?stockno=6184558&src-supplier=RSComponents; ComponentLink3Description: http://www.farnell.com/datasheets/1018594.pdf?src-supplier=Element14; ComponentLink3URL: http://www.farnell.com/datasheets/1018594.pdf?src-supplier=Element14; ComponentLink4Description: https://www.verical.com/server-webapp/html/getDatasheet?partID=328119&src-supplier=Verical; ComponentLink4URL: https://www.verical.com/server-webapp/html/getDatasheet?partID=328119&src-supplier=Verical; ComponentLink5Description: http://www.farnell.com/datasheets/1686128.pdf?src-supplier=Element14; ComponentLink5URL: http://www.farnell.com/datasheets/1686128.pdf?src-supplier=Element14; Composition: Thick Film; Depth: 0.5mm; Height: 0.32mm; Lead Free: Lead Free; Length: 1mm; Library Name: Resistor_0402.IntLib; Max Operating Temperature: 155°C; Military Standard: Not; Min Operating Temperature: -55°C; Number of Pins: 2; Packaging: Tape and Reel; Pricing 1: 1=0.1, 10=0.016, 100=0.0067, 1000=0.00301, 2500=0.00261, 5000=0.00215 (USD); REACH SVHC: No SVHC; Signal Integrity: Resistor; Simulation: Resistor; Stock 1: 27955304; Supplier 1: Digi-Key; Supplier Part Number 1: 311-4.7KLRDKR-ND; Termination: SMD/SMT; Tolerance: 1%; Voltage Rating (DC): 50V; Width: 0.5mm
R50 = RC0603JR-07750RL: RES, 750, 5%, 0.1 W, 0603; Manufacturer: Yageo America; AEC Category: Q200; CompType: Resistor; EIA_Code: E24; Power_W: 0.1; SizeArea_mm2: 1.28; SizeX_mm: 1.6; SizeY_mm: 0.8; SizeZ_mm: 1; TempCo: 100 ppm/C; Tolerance_%: 5; U/M: EA; Value2: 0.1W; Value_Code: 750R; VDC_V: 50; AEC Qualified: ?; Component Kind: Standard; ComponentLink1Description: Datasheet link; ComponentLink1URL: http://www.yageo.com/pdf/Pu-RC0603_51_PbFree_L_2.pdf; LatestRevisionDate: 2016-11-02; Library Name: Resistor_0603.IntLib; MountType: SMT; PackageReference: 0603; PartNumber: RC0603JR-07750RL; Published: 2008-06-26; Res_ohm: 750; RoHS: Y; Signal Integrity: Resistor; Simulation: Resistor; Supplier 1: Digi-Key; Supplier 2: Mouser; Supplier 3: Farnell; Supplier Part Number 1: 311-750GRCT-ND; Supplier Part Number 2: 603-RC0603JR-07750RL; Supplier Part Number 3: 1799450; SymbolVersion: 2009-05-29; Technology: Thick Film; Value1: 5%; ValueDisplayed: 750; Publisher: Texas Instruments
R53 R72 = CRCW0402100KJNED: RES, 100 k, 5%, 0.063 W, 0402; Manufacturer: Vishay-Dale; AEC Category: Q200; CompType: Resistor; EIA_Code: E24; Power_W: 0.063; Res_ohm: 100000; SizeArea_mm2: 0.5; SizeX_mm: 1; SizeZ_mm: 0.6; TempCo: 200 ppm/C; Tolerance_%: 5; U/M: EA; Value2: 0.063W; VDC_V: 50; AEC Qualified: ?; Component Kind: Standard; ComponentLink1Description: Datasheet link; ComponentLink1URL: http://www.vishay.com/docs/20035/dcrcwe3.pdf; LatestRevisionDate: 2016-07-01; Library Name: Resistor_0402.IntLib; MountType: SMT; PackageReference: 0402; PartNumber: CRCW0402100KJNED; Published: 2008-06-26; RoHS: Y; Signal Integrity: Resistor; Simulation: Resistor; SizeY_mm: 0.5; Supplier 1: Digi-Key; Supplier 2: Mouser; Supplier 3: Farnell; Supplier Part Number 1: 541-100KJCT-ND; Supplier Part Number 2: 71-CRCW0402J-100K-E3; Supplier Part Number 3: 1652738; SymbolVersion: 2009-05-29; Technology: Thick Film; Value1: 5%; Value_Code: 100k; ValueDisplayed: 100k; Publisher: Texas Instruments
R55 R56 = CRCW040210K0JNED: RES, 10 k, 5%, 0.063 W, 0402; Manufacturer: Vishay-Dale; AEC Category: Q200; CompType: Resistor; EIA_Code: E24; Power_W: 0.063; Res_ohm: 10000; SizeArea_mm2: 0.5; SizeX_mm: 1; SizeZ_mm: 0.6; TempCo: 200 ppm/C; Tolerance_%: 5; U/M: EA; Value: 10k; Value2: 0.063W; Value_Code: 10k0; VDC_V: 50; AEC Qualified: ?; Component Kind: Standard; ComponentLink1Description: Datasheet link; ComponentLink1URL: http://www.vishay.com/docs/20035/dcrcwe3.pdf; LatestRevisionDate: 2016-07-01; Library Name: Resistor_0402.IntLib; MountType: SMT; PackageReference: 0402; PartNumber: CRCW040210K0JNED; Published: 2008-06-26; RoHS: Y; Signal Integrity: Resistor; Simulation: Resistor; SizeY_mm: 0.5; Supplier 1: Digi-Key; Supplier 2: Mouser; Supplier 3: Farnell; Supplier Part Number 1: 541-10KJCT-ND; Supplier Part Number 2: 71-CRCW0402J-10K-E3; Supplier Part Number 3: 1514663; SymbolVersion: 2009-05-29; Technology: Thick Film; Value1: 5%; Publisher: Texas Instruments
U2 = NC7WZ17P6X: NC7WZ17 Series 5.5 V TinyLogic UHS Dual Buffer w/ Schmitt Trigger Inputs-SC-70-6; MF: ON Semiconductor; Availability: Unavailable; Component Kind: Standard; Library Name: NC7WZ17P6X.IntLib; MP: NC7WZ17P6X; Package: SC-70-6 ON Semiconductor; Price: None; SnapEDA_Link: https://www.snapeda.com/parts/NC7WZ17P6X/ON%20Semiconductor/view-part/?ref=snap
U3 = TPS62162DSGR: Buck Step Down Regulator with 3 to 17 V Input and 3.3 V Output, -40 to 85 degC, 8-Pin WSON (DSG), Green (RoHS & no Sb/Br); Alternate Manufacturer: Texas Instruments; Alternate PartNumber: Equivalent; Duty Cycle(Max)(%): 100; Iout(Max)(A): 1; Iq(Typ)(mA): 0.017; Preset Vout(V): 3.3; Rating: Catalog; SubFamily: Step-Down Regulator; Switch Current Limit(Typ)(A): 1.8; Synchronous Rectification: TRUE; Vin(Max)(V): 17; Vin(Min)(V): 3; Code_JEDEC: MO-229; Component Kind: Standard; ComponentLink1Description: Datasheet; ComponentLink1URL: http://www.ti.com/general/docs/lit/getliterature.tsp?genericPartNumber=TPS62162&fileType=pdf; ComponentLink2Description: URL Link1; ComponentLink2URL: Samples URL:http://www.ti.com/product/tps62162#samples; DatasheetVersion: SLVSAM2A; Eco-Plan: Green (RoHS & no Sb/Br); Library Name: TI Power Management Step-Down Regulator.IntLib; Manufacturer: Texas Instruments; MountType: SMT; PackageDescription: 8-Pin Plastic Small Outline No-Lead, 2 x 2 x 0.8 mm Body, 0.5 mm Pitch, Exposed Pad; PackageReference: DSG0008A; PackageVersion: revB, Oct-2010; PartNumber: TPS62162DSGR; Regulated Outputs(#): 1; Supplier 1: Digi-Key; Supplier 2: Newark; Supplier 3: Mouser; Supplier Part Number 1: TPS62162DSGR-ND; Supplier Part Number 2: 74T5557; Supplier Part Number 3: 595-TPS62162DSGR; Topology: Buck; Vout(Max)(V): 3.3; Vout(Min)(V): 3.3
U4 = Apple CP: Auth 3.0 CP; MF: Apple; MP: MFI343S00177; Component Kind: Standard; Library Name: MFI337S3959.SchLib; Package: 8-XDFN; Supplier: Avnet
U5 = SHT30-DIS-B: SHT3x Series 5.5 V Â±3 %RH 0 to 100% Temperature and Humidity Sensor - DFN-8; MF: Sensirion AG; Availability: Unavailable; Component Kind: Standard; Library Name: SHT30-DIS-B.SchLib; MP: SHT30-DIS-B; Package: DFN-8 Sensirion; Price: None; SnapEDA_Link: https://www.snapeda.com/parts/SHT30-DIS-B/Sensirion%20AG/view-part/?ref=snap; Supplier 1: Digi-Key
TP6-TP19 TP21 TP31-TP36 TP58 = WIREPT: Component Kind: Standard
  TP6-TP18 TP21 TP31-TP36: Library Name: EAGLE_CAD_Library.SchLib
  TP19 TP58: Library Name: AIRZAI_MBD_REVB.IntLib
TP60 TP61 = TESTPOINT: Component Kind: Standard; Library Name: AIRZAI_LID_BD_REVA.IntLib
